# Altium schematic sheet 5.04.51805A02_RKKey_RGB_TF.SchDoc(5.04.51805A02_RKKey_RGB_TF)
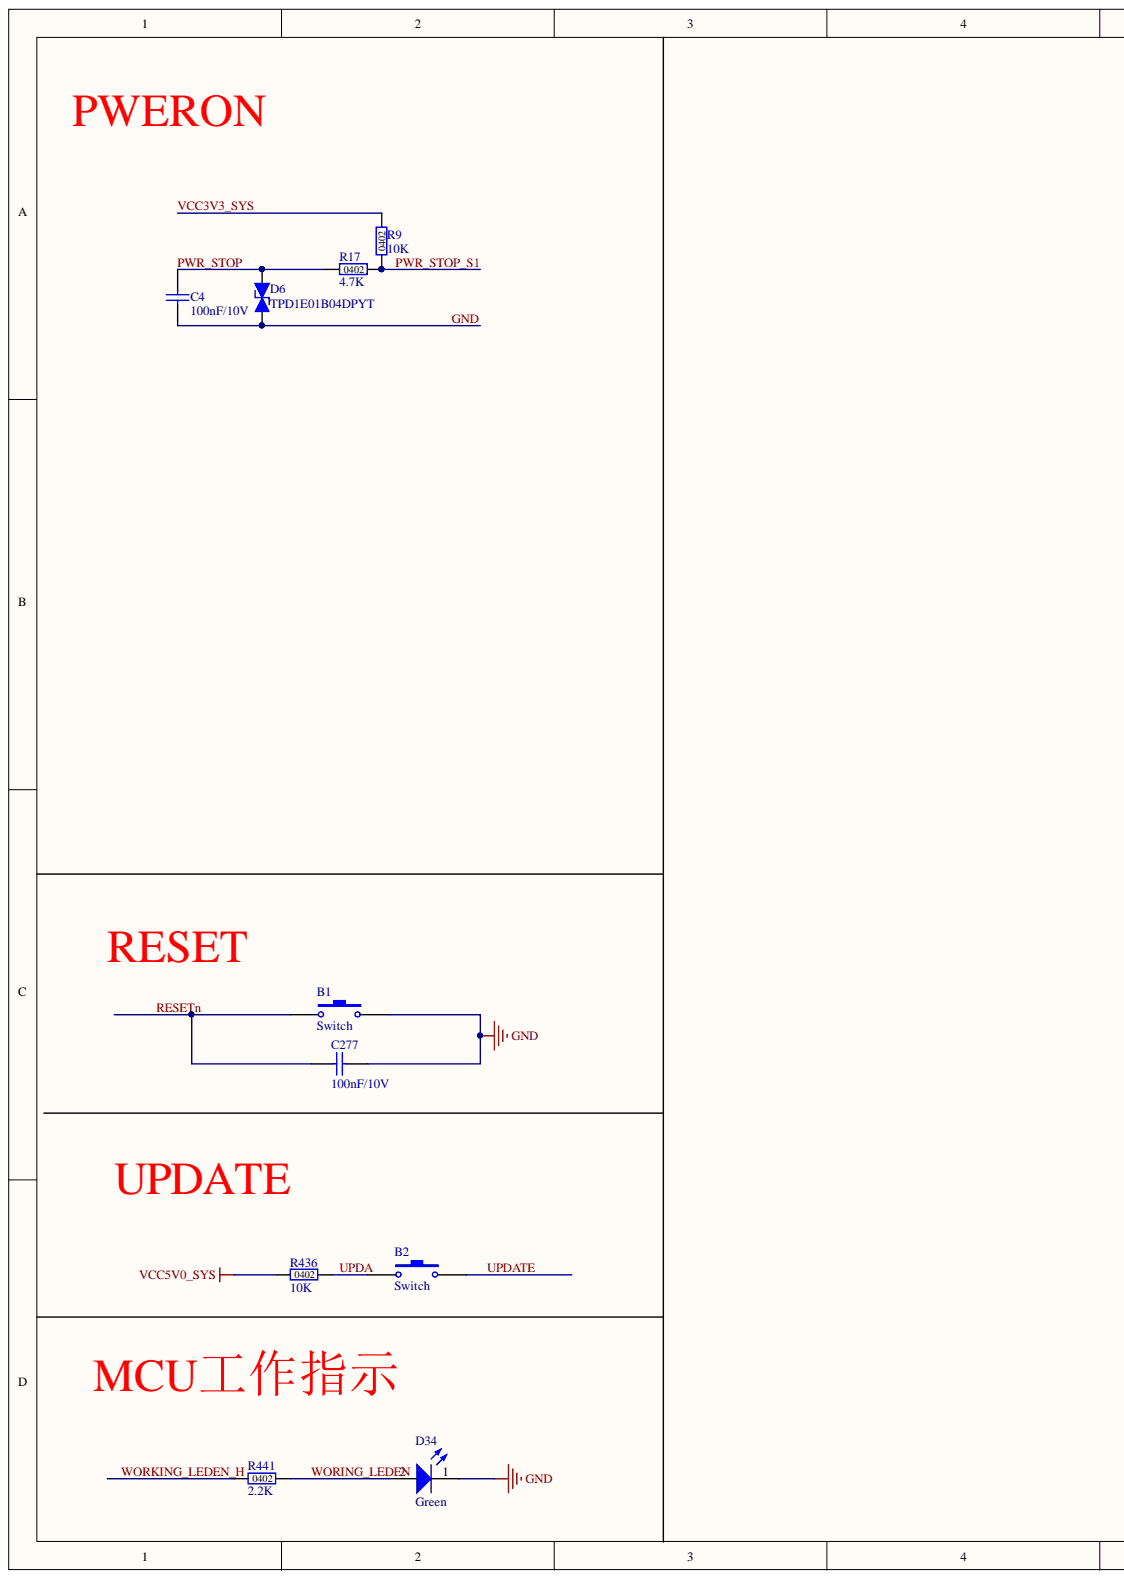
[diagram p0: 5.04.51805A02_RKKey_RGB_TF.SchDoc, left]
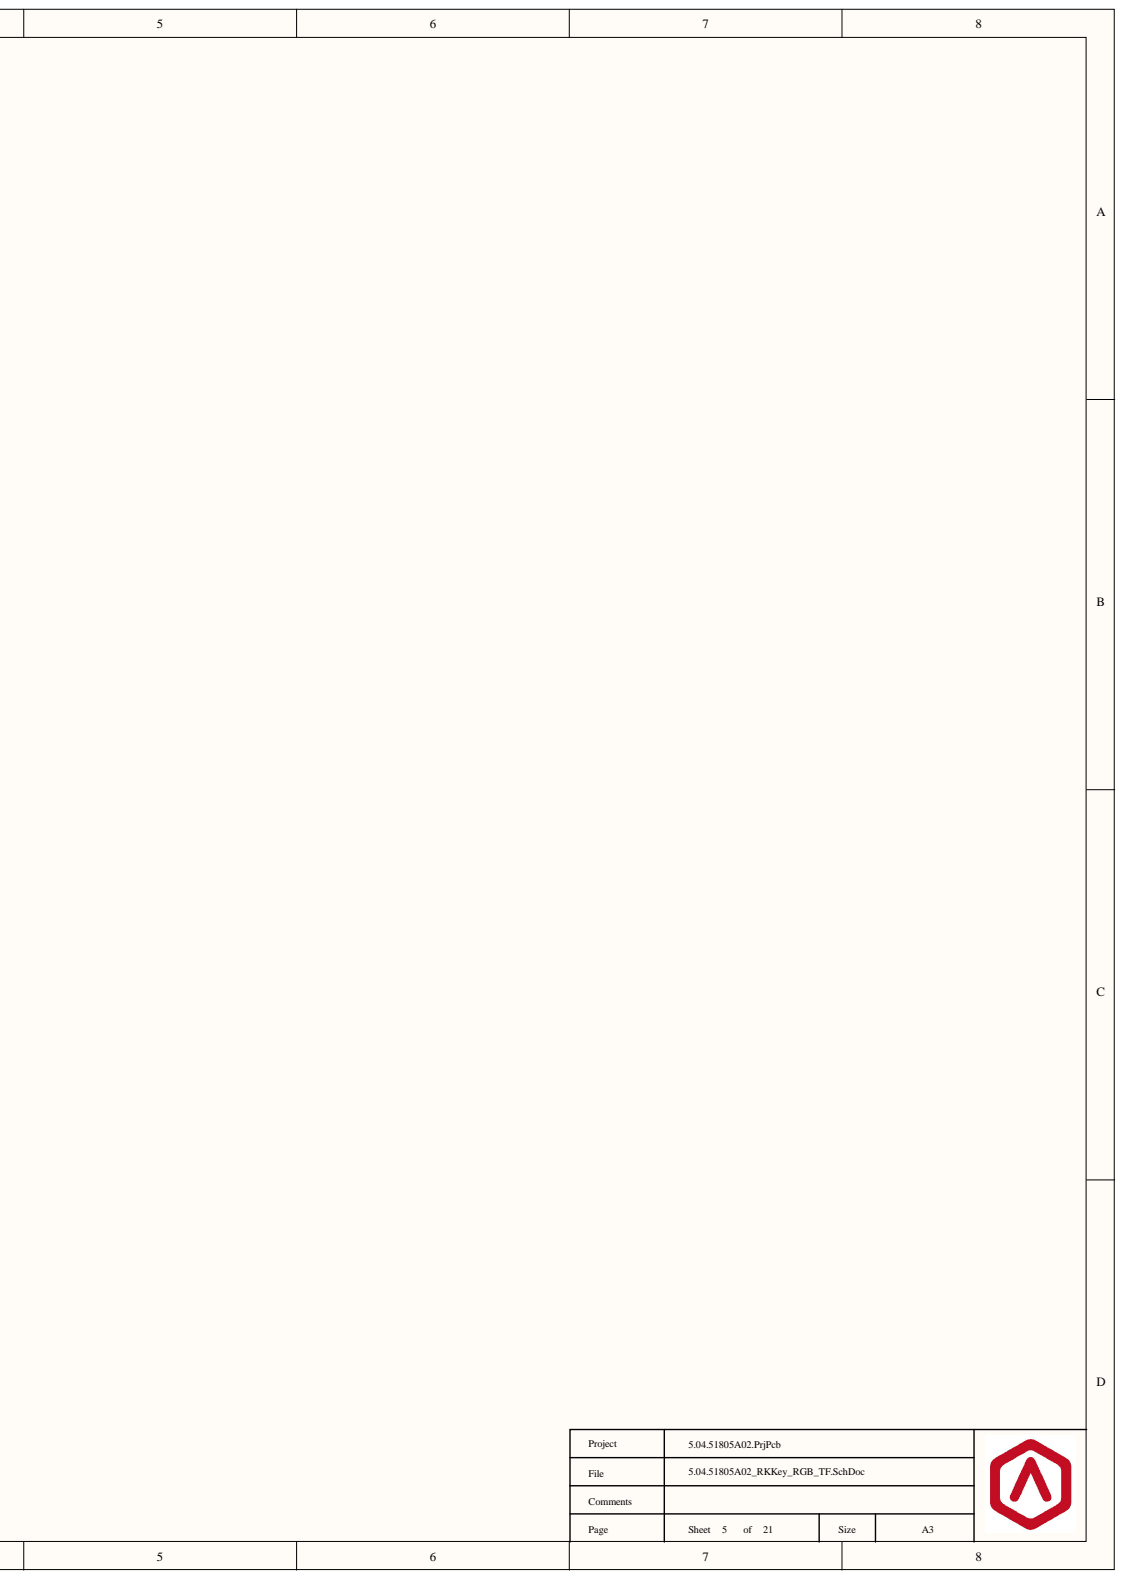
[diagram p1: 5.04.51805A02_RKKey_RGB_TF.SchDoc, right]

## Parts
B1  Switch  [TS-021]
B2  Switch  [TS-021]
C4  100nF/10V  [C0402]
C277  100nF/10V  [C0402]
D6  TPD1E01B04DPYT  [TVS_0402]
D34  Green  [LED0603_2]
R9  10K  [R0402]
R17  4.7K  [R0402]
R436  10K  [R0402]
R441  2.2K  [R0402]

## Nets
VCC5V0_SYS: R436.1
GND: B1.2 C4.1 C277.2 D6.1 D34.1
PWR_STOP: C4.2 D6.2 R17.1
PWR_STOP_S1: R9.1 R17.2
RESETN: B1.1 C277.1
UPDA: B2.1 R436.2
UPDATE: B2.2
VCC3V3_SYS: R9.2
WORING_LEDEN: D34.2 R441.2
WORKING_LEDEN_H: R441.1

## Library data of the parts
B1 B2 = B_TS-021: 开关,TS-021,DC12V50mA,30mOhm,SPST,垂直,SMT,-30°C~70°C; Manufacturer1: 东莞合智电子; Code: 57010003; Component Kind: Standard; ManufacturerPartNumber1: TS-021; Library Name: Raise3D_Switch&Optocoupler.SCHLIB
C4 C277 = C0402_100nF_10V: Ceramic Capacitor, 100nF, ±10%, 10V, -55°C~125°C, C0402; Manufacturer1: MuRata; ManufacturerPartNumber1: GRM155R71A104KA01D; Code: 5161C104; Component Kind: Standard; Library Name: Raise3D_Capacitor.IntLib
D6 = TVS_TPD1E01B04DPYT: TVS二极管,TPD1E01B04DPYT,3.6V,1Channel,+/-17kV,+/-15kV,X1SON-2,-40°C~125°C; Manufacturer1: TexasInstruments; Code: 53040002; Component Kind: Standard; ManufacturerPartNumber1: TPD1E01B04DPYT; Library Name: Raise3D_Diode.IntLib
D34 = LED_APT1608CGCK: LED,APT1608CGCK,Green,2.5V,TOP,LED0603,-40°C~85°C; Manufacturer1: Kingbright; Code: 53010003; Component Kind: Standard; ManufacturerPartNumber1: APT1608CGCK; Library Name: Raise3D_Diode.SCHLIB
R9 R436 = R0402_10K: Resistor 10Kohm,1%,1/16W,R0402; Manufacturer1: Yageo; ManufacturerPartNumber1: RC0402FR-0710KL; Code: 5001010K; Component Kind: Standard; Manufacturer2: Samsung; ManufacturerPartNumber2: RC1005F103CS; Library Name: Raise3D_Resistor.IntLib
R17 = R0402_4.7K: Resistor 4.7Kohm,5%,1/16W,R0402; Manufacturer1: Yageo; ManufacturerPartNumber1: RC0402JR-074K7L; Code: 501104K7; Component Kind: Standard; Manufacturer2: Samsung; ManufacturerPartNumber2: RCS1005J472CS; Library Name: Raise3D_Resistor.IntLib
R441 = R0402_2.2K: Resistor 2.2Kohm,1%,1/16W,R0402; Manufacturer1: Samsung; ManufacturerPartNumber1: RC1005F222CS; Code: 500102K2; Component Kind: Standard; Library Name: Raise3D_Resistor.IntLib
